# Altium schematic sheet RS485.SchDoc
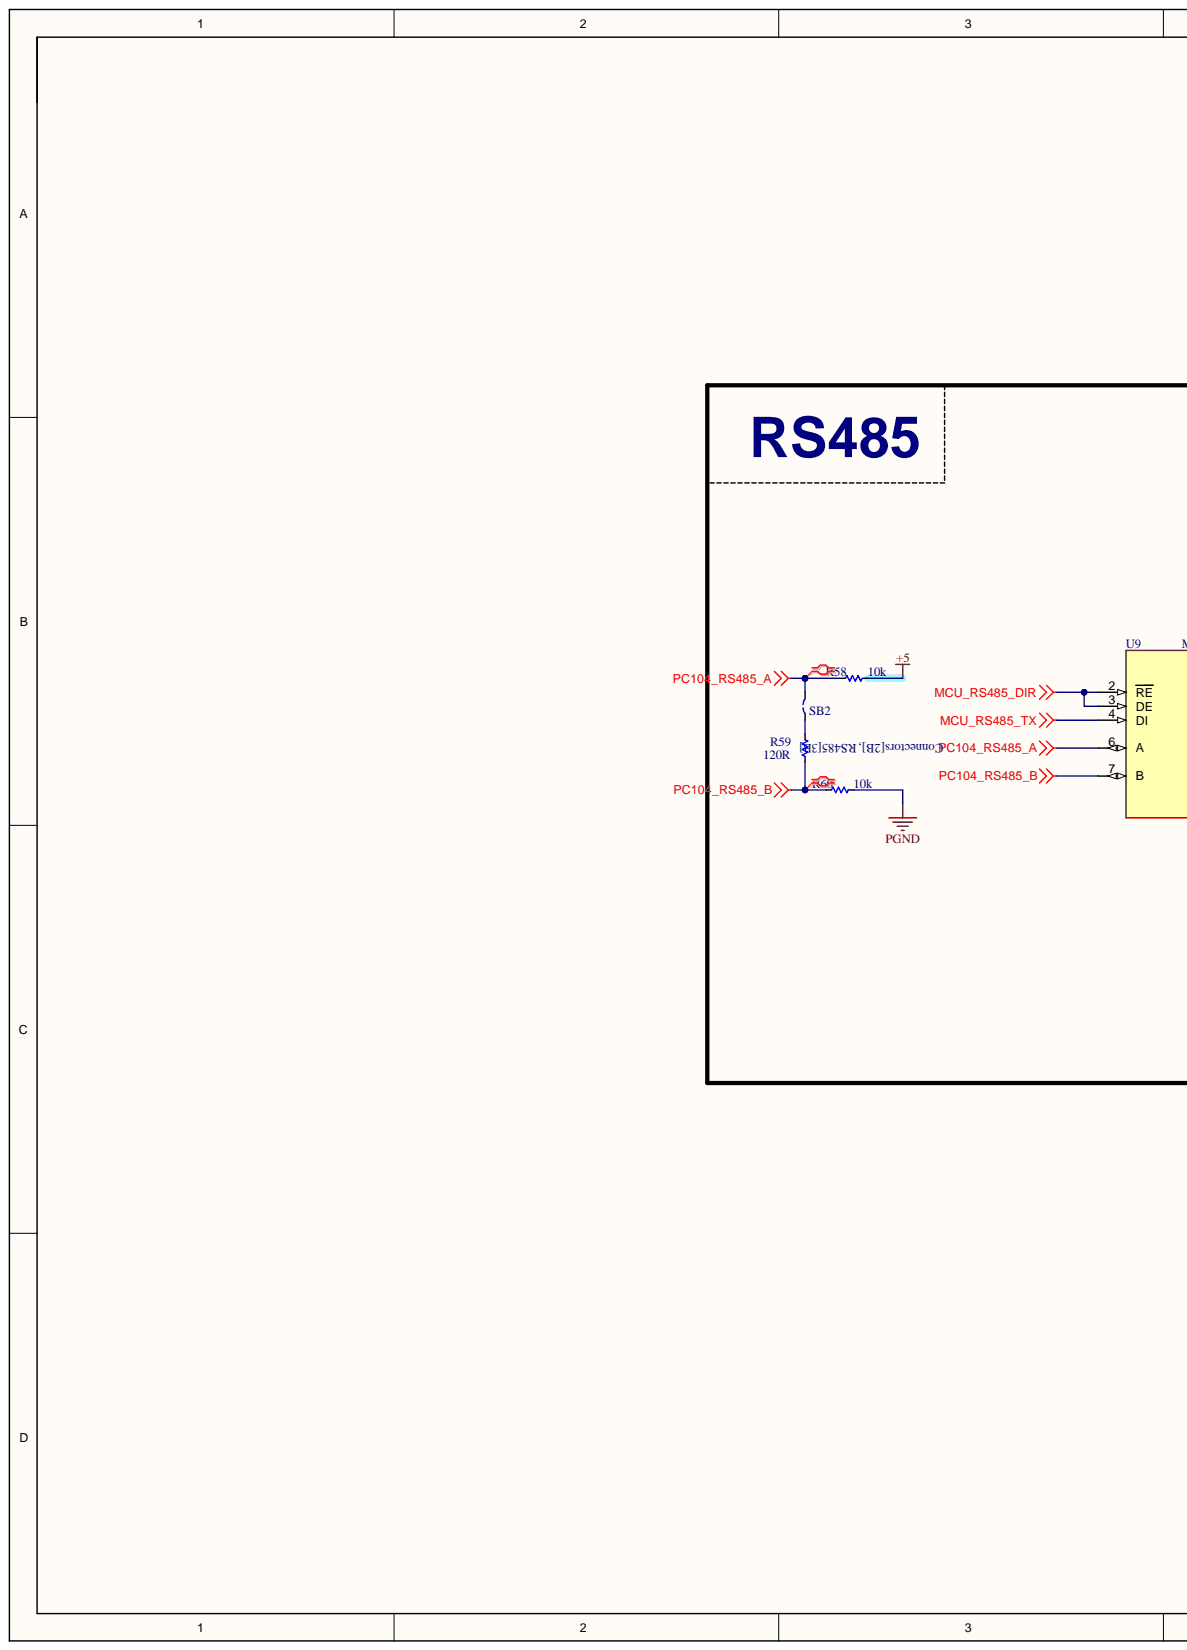
[diagram p0: RS485.SchDoc, left]
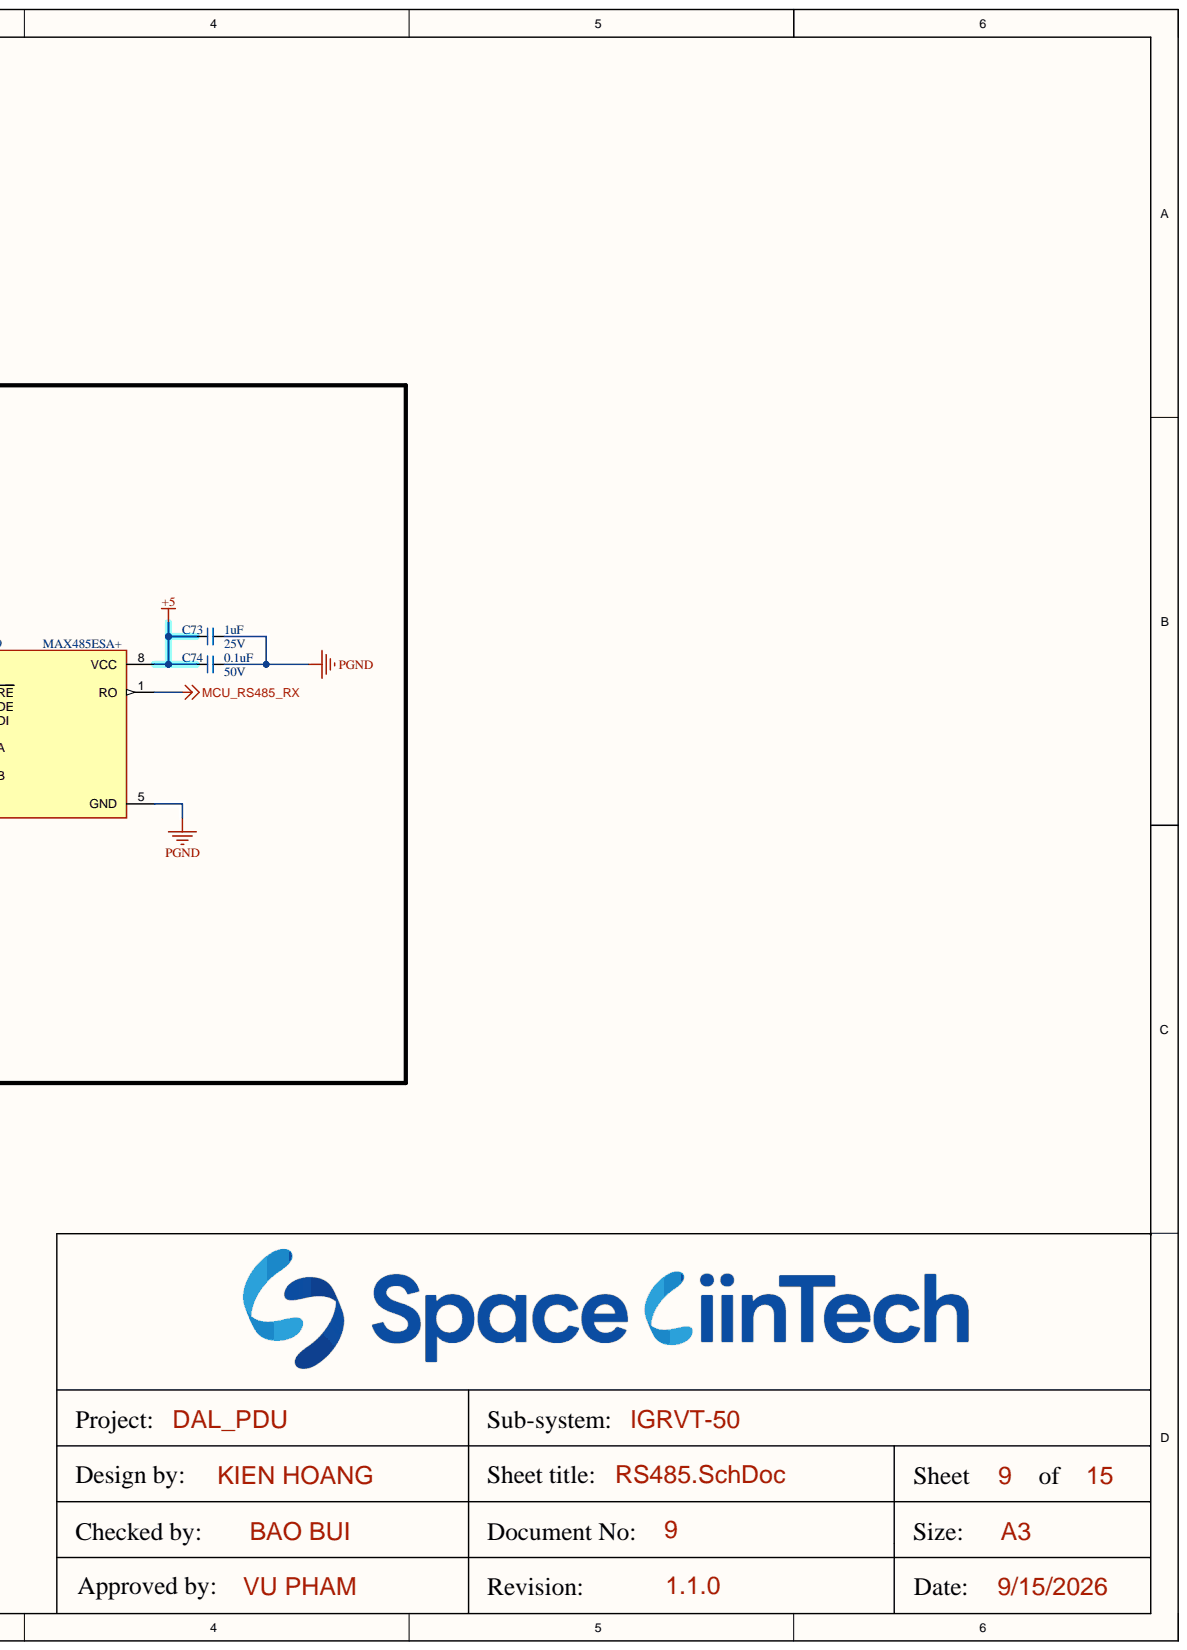
[diagram p1: RS485.SchDoc, right]

## Parts
C73  1uF  Voltage: 25V  [CAP_C_1608X80]
C74  0.1uF  Voltage: 50V  [CAP_C_1608X80]
R58  10k  [RES_C_1608X60]
R59  120R  [RES_C_1608X60]
R60  10k  [RES_C_1608X60]
SB2  N/A  [JUMPER_1608]  (value not drawn)
U9  MAX485ESA+  [SOIC127]

## Nets
+5: C73.2 C74.2 R58.2 U9.8(VCC)
MCU_RS485_DIR: U9.2(RE) U9.3(DE)
MCU_RS485_RX: U9.1(RO)
MCU_RS485_TX: U9.4(DI)
PC104_RS485_A: R58.1 SB2.2 U9.6(A)
PC104_RS485_B: R59.1 R60.1 U9.7(B)
PGND: C73.1 C74.1 R60.2 U9.5(GND)
NetR59_2: R59.2 SB2.1

## Library data of the parts
C73 C74 = _CAP_C_2: CAP; Component Kind: Standard; Library Name: LABLIB.SchLib
R58-R60 = _RES_B_2_2: RES; Component Kind: Standard; Library Name: LABLIB.SchLib
SB2 = JUMPER: Component Kind: Standard; Library Name: LV.SchLib
U9 = MAX485ESA+: Component Kind: Standard; Library Name: KIENASIY123_LIB.IntLib
